# Altium schematic sheet LIFT_CARD.SchDoc(LIFT_CARD)
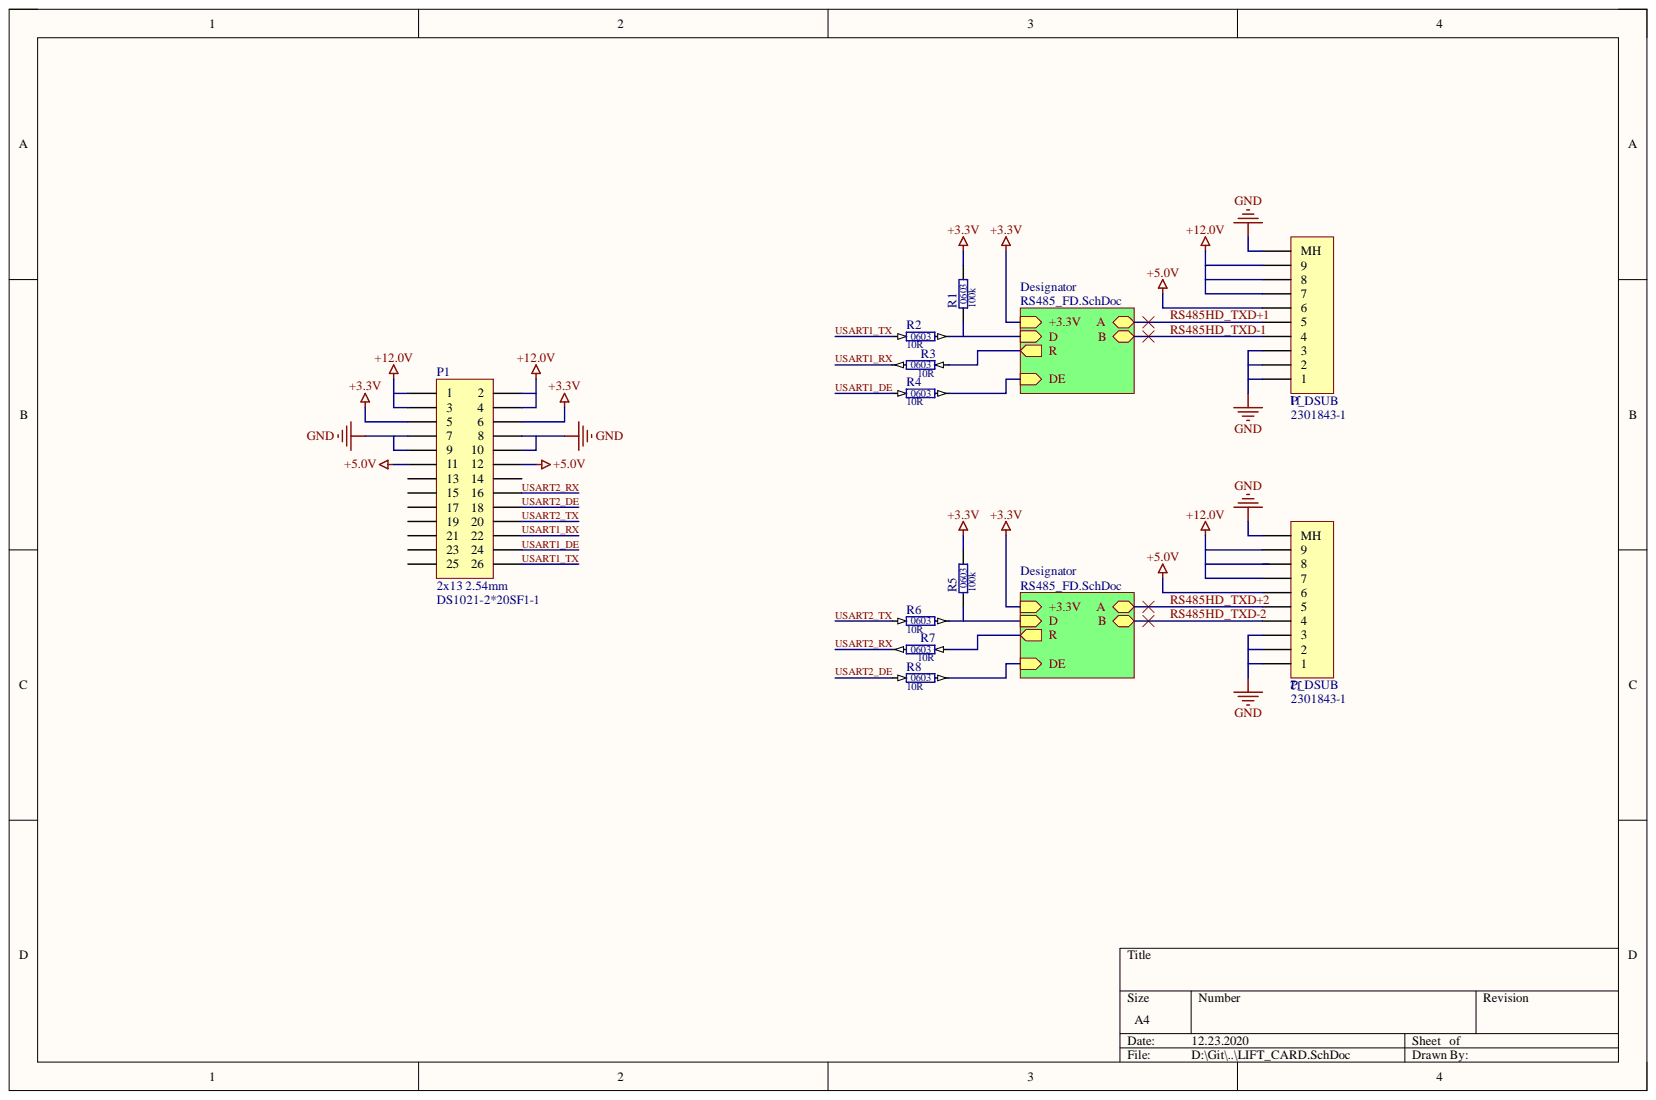
[diagram p0: LIFT_CARD.SchDoc]

## Parts
J1  value 2301843-1  Manufacturer Part Number: 2301843-1  SCH_Footprint: P_DSUB  [P_DSUB_2301843-1]  (hidden comment: DSUB 9 Pin)
J2  value 2301843-1  Manufacturer Part Number: 2301843-1  SCH_Footprint: P_DSUB  [P_DSUB_2301843-1]  (hidden comment: DSUB 9 Pin)
P1  value DS1021-2*20SF1-1  Manufacturer Part Number: DS1021-2*20SF1-1  SCH_Footprint: 2x13 2.54mm  [P_HEADER_2x13_2.54]  (hidden comment: Goldpin male)
R1  value 100k  SCH_Footprint: 0603  [R_0603_1608]  (hidden comment: Resistor)
R2  value 10R  SCH_Footprint: 0603  [R_0603_1608]  (hidden comment: Resistor)
R3  value 10R  SCH_Footprint: 0603  [R_0603_1608]  (hidden comment: Resistor)
R4  value 10R  SCH_Footprint: 0603  [R_0603_1608]  (hidden comment: Resistor)
R5  value 100k  SCH_Footprint: 0603  [R_0603_1608]  (hidden comment: Resistor)
R6  value 10R  SCH_Footprint: 0603  [R_0603_1608]  (hidden comment: Resistor)
R7  value 10R  SCH_Footprint: 0603  [R_0603_1608]  (hidden comment: Resistor)
R8  value 10R  SCH_Footprint: 0603  [R_0603_1608]  (hidden comment: Resistor)

## Nets
+3.3V: P1.5 P1.6 R1.2 R5.2
+5.0V: J1.6 J2.6 P1.11 P1.12
+12.0V: J1.7 J1.8 J1.9 J2.7 J2.8 J2.9 P1.1 P1.2 P1.3 P1.4
GND: J1.1 J1.2 J1.3 J1.MH J2.1 J2.2 J2.3 J2.MH P1.7 P1.8 P1.9 P1.10
RS485HD_TXD+1: J1.5
RS485HD_TXD+2: J2.5
RS485HD_TXD-1: J1.4
RS485HD_TXD-2: J2.4
USART1_DE: P1.24 R4.1
USART1_RX: P1.22 R3.2
USART1_TX: P1.26 R2.1
USART2_DE: P1.18 R8.1
USART2_RX: P1.16 R7.2
USART2_TX: P1.20 R6.1
NetP1_13: P1.13
NetP1_14: P1.14
NetP1_15: P1.15
NetP1_17: P1.17
NetP1_19: P1.19
NetP1_21: P1.21
NetP1_23: P1.23
NetP1_25: P1.25
NetR1_1: R1.1 R2.2
NetR3_1: R3.1
NetR4_2: R4.2
NetR5_1: R5.1 R6.2
NetR7_1: R7.1
NetR8_2: R8.2

## Library data of the parts
J1 J2 = P_DSUB_2301843-1: Header, 9-Pin; Manufacturer: TE Conectivity; Database Table Name: Connectors; Component Kind: Standard; HelpURL: https://pl.mouser.com/datasheet/2/418/1/NG_CD_2301843_H-1517790.pdf; Library Name: Library.DBLib; Part Number: P_DSUB_2301843-1; Supplier 1: TME; Supplier 2: RS Components; Supplier 3: Farnell; Supplier 4: Mouser; Supplier 5: Digi-Key; Supplier 6: LCSC; Supplier Part Number 3: 2857982; Supplier Part Number 4: 571-2301843-1; Supplier Part Number 5: A132510-ND
P1 = P_HEADER_2x13_2.54: Pin Header; Manufacturer: Connfly; Database Table Name: Connectors; Component Kind: Standard; HelpURL: https://www.tme.eu/Document/cc301e58a5da78cb543a94b5a5b7f06a/DS1021.pdf; Library Name: Library.DBLib; Part Number: P_HEADER_2x13_2.54; Supplier 1: TME; Supplier 2: RS Components; Supplier 3: Farnell; Supplier 4: Mouser; Supplier 5: Digi-Key; Supplier 6: LCSC; Supplier Part Number 1: ZL202-40G
R1 R5 = R_100k_0603_1: Manufacturer: Royalohm; Manufacturer Part Number: 0603SAF1003T5E; Database Table Name: Resistors; Power: 100mW; Tolerance: 1%; Voltage: 50V; Component Kind: Standard; HelpURL: http://www.tme.eu/pl/Document/b315665a56acbc42df513c99b390ad98/ROYALOHM-THICKFILM.pdf; Library Name: Library.DBLib; Part Number: R_100k_0603_1; Supplier 1: TME; Supplier 2: RS Components; Supplier 3: Farnell; Supplier 4: Mouser; Supplier 5: Digi-Key; Supplier 6: LCSC; Supplier Part Number 1: SMD0603-100K-1%; Supplier Part Number 4: 667-ERJ-3EKF1003V; Supplier Part Number 5: P100KHCT-ND
R2-R4 R6-R8 = R_10R_0603_1: Manufacturer: Royalohm; Manufacturer Part Number: 0603SAF100JT5E; Database Table Name: Resistors; Power: 100mW; Tolerance: 1%; Voltage: 50V; Component Kind: Standard; HelpURL: http://www.tme.eu/pl/Document/b315665a56acbc42df513c99b390ad98/ROYALOHM-THICKFILM.pdf; Library Name: Library.DBLib; Part Number: R_10R_0603_1; Supplier 1: TME; Supplier 2: RS Components; Supplier 3: Farnell; Supplier 4: Mouser; Supplier 5: Digi-Key; Supplier Part Number 1: SMD0603-10R-1%
